# Altium schematic sheet POWER.SchDoc(U_POWER)
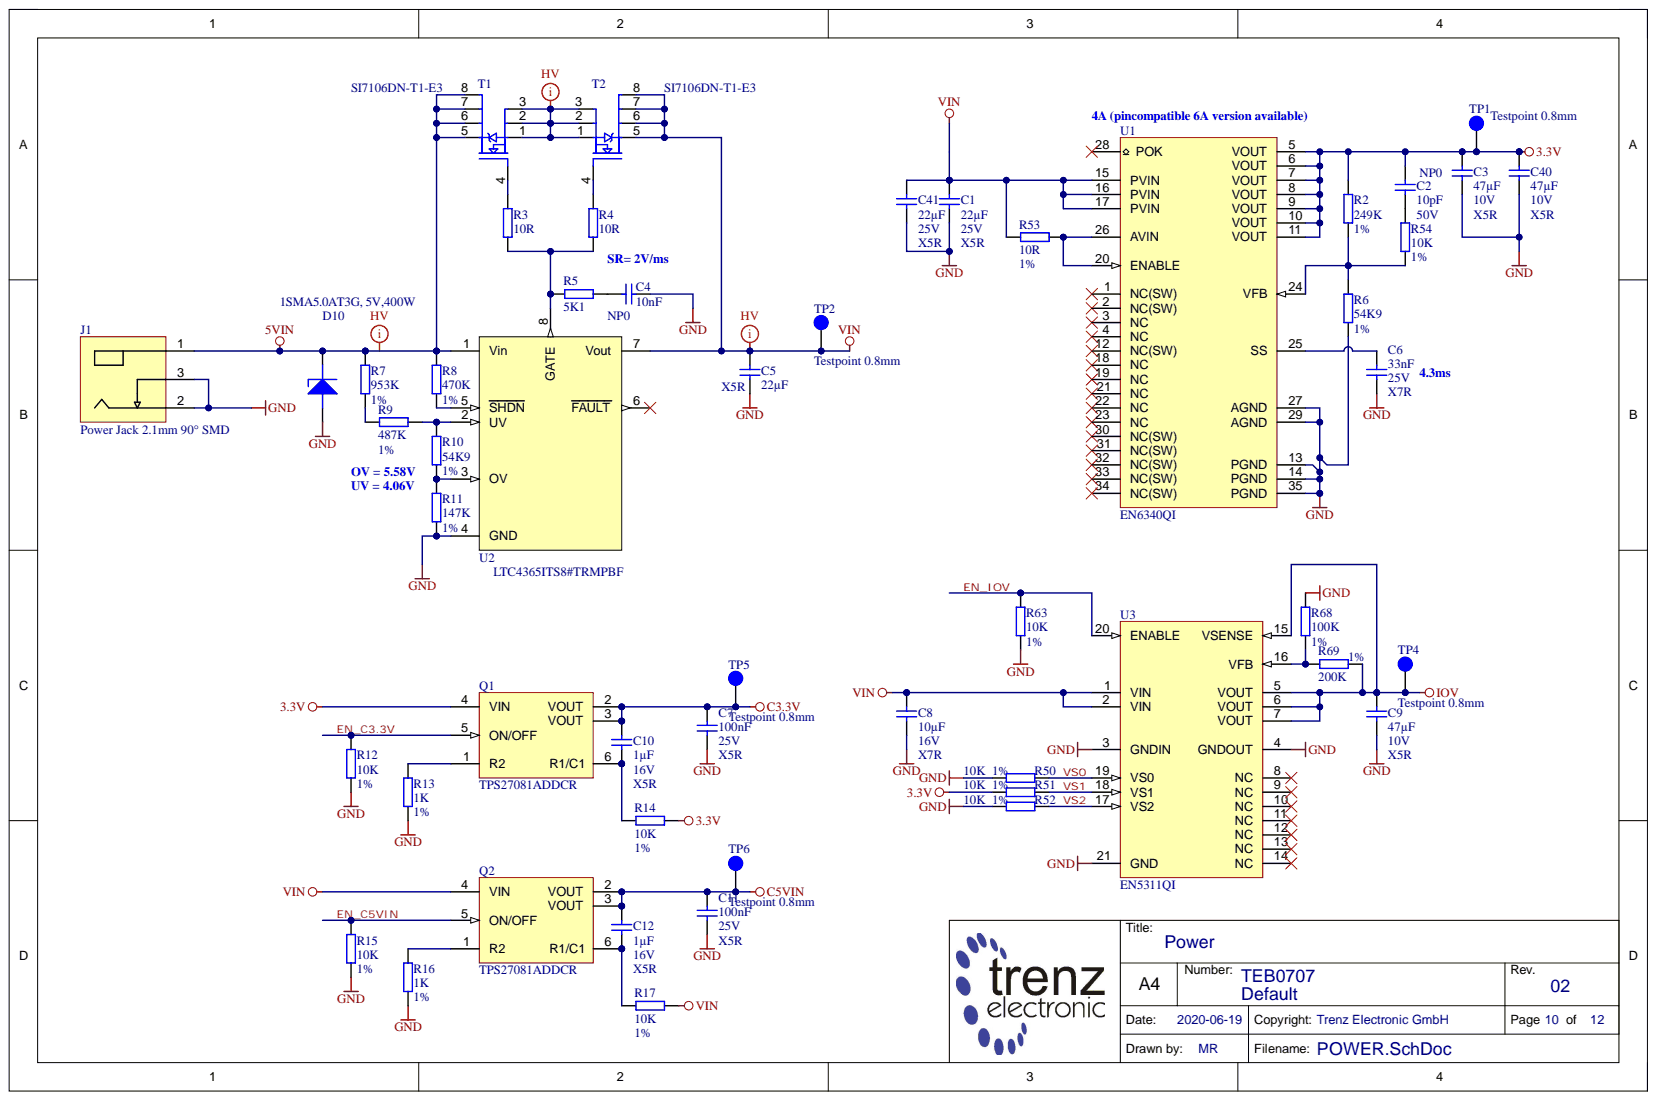
[diagram p0: POWER.SchDoc]

## Parts
C1  22µF  Technology: X5R  Voltage: 25V  [CAPC3216X180N]
C2  10pF  Technology: NP0  Voltage: 50V  [CAPC1005X55N]
C3  47µF  Technology: X5R  Voltage: 10V  [CAPC2012X135N]
C4  10nF  [CAPC1608X90N]
C5  22µF  Technology: X5R  [CAPC3216X180N]
C6  33nF  Technology: X7R  Voltage: 25V  [CAPC1005X55N]
C7  100nF  Technology: X5R  Voltage: 25V  [CAPC1005X55N]
C8  10µF  Technology: X7R  Voltage: 16V  [CAPC2012X135N]
C9  47µF  Technology: X5R  Voltage: 10V  [CAPC2012X135N]
C10  1µF  Technology: X5R  Voltage: 16V  [CAPC1005X55N_V]
C11  100nF  Technology: X5R  Voltage: 25V  [CAPC1005X55N]
C12  1µF  Technology: X5R  Voltage: 16V  [CAPC1005X55N]
C40  47µF  Technology: X5R  Voltage: 10V  [CAPC2012X135N]
C41  22µF  Technology: X5R  Voltage: 25V  [CAPC3216X180N]
D10  1SMA5.0AT3G, 5V,400W  [DIOM5226X220N]
J1  Power Jack 2.1mm 90° SMD  [694106106102]
Q1  TPS27081ADDCR  [SOT95P280X110-6N]
Q2  TPS27081ADDCR  [SOT95P280X110-6N]
R2  249K  Tolerance: 1%  [RESC1005X40N]
R3  10R  [RESC1005X40N]
R4  10R  [RESC1005X40N]
R5  5K1  [RESC1005X40N]
R6  54K9  Tolerance: 1%  [RESC1005X40N]
R7  953K  Tolerance: 1%  [RESC1005X40N]
R8  470K  Tolerance: 1%  [RESC1005X40N]
R9  487K  Tolerance: 1%  [RESC1005X40N]
R10  54K9  Tolerance: 1%  [RESC1005X40N]
R11  147K  Tolerance: 1%  [RESC1005X40N]
R12  10K  Tolerance: 1%  [RESC1005X40N]
R13  1K  Tolerance: 1%  [RESC1005X40N]
R14  10K  Tolerance: 1%  [RESC1005X40N]
R15  10K  Tolerance: 1%  [RESC1005X40N]
R16  1K  Tolerance: 1%  [RESC1005X40N]
R17  10K  Tolerance: 1%  [RESC1005X40N]
R50  10K  Tolerance: 1%  [RESC1005X40N]
R51  10K  Tolerance: 1%  [RESC1005X40N]
R52  10K  Tolerance: 1%  [RESC1005X40N]
R53  10R  Tolerance: 1%  [RESC1005X40N]
R54  10K  Tolerance: 1%  [RESC1005X40N]
R63  10K  Tolerance: 1%  [RESC1005X40N]
R68  100K  Tolerance: 1%  [RESC1005X40N]
R69  200K  Tolerance: 1%  [RESC1005X40N]
T1  SI7106DN-T1-E3  [Si7106]
T2  SI7106DN-T1-E3  [Si7106]
TP1  Testpoint 0.8mm  [TP_0.8mm]
TP2  Testpoint 0.8mm  [TP_0.8mm]
TP4  Testpoint 0.8mm  [TP_0.8mm]
TP5  Testpoint 0.8mm  [TP_0.8mm]
TP6  Testpoint 0.8mm  [TP_0.8mm]
U1  EN6340QI  [EN6363]
U2  LTC4365ITS8#TRMPBF  [TSOT-23-8]
U3  EN5311QI  [EN5311QI]

## Nets
3.3V: C2.1 C3.2 C40.2 Q1.4(VIN) R2.1 R14.2 R51.2 TP1.1 U1.5(VOUT) U1.6(VOUT) U1.7(VOUT) U1.8(VOUT) U1.9(VOUT) U1.10(VOUT) U1.11(VOUT)
5VIN: D10.1 J1.1 R7.1 R8.1 T1.5 T1.6 T1.7 T1.8 U2.1(Vin)
C3.3V: C7.2 C10.2 Q1.2(VOUT) Q1.3(VOUT) TP5.1
C5VIN: C11.2 C12.2 Q2.2(VOUT) Q2.3(VOUT) TP6.1
GND: C1.1 C3.1 C4.2 C5.1 C6.2 C7.1 C8.2 C9.2 C11.1 C40.1 C41.1 D10.2 J1.2 J1.3 R6.2 R11.2 R12.2 R13.1 R15.2 R16.1 R50.2 R52.2 R63.2 R68.1 U1.13(PGND) U1.14(PGND) U1.27(AGND) U1.29(AGND) U1.35(PGND) U2.4(GND) U3.3(GNDIN) U3.4(GNDOUT) U3.21(GND)
IOV: C9.1 R69.2 TP4.1 U3.5(VOUT) U3.6(VOUT) U3.7(VOUT) U3.15(VSENSE)
VIN: C1.2 C5.2 C8.1 C41.2 Q2.4(VIN) R17.2 R53.1 T2.5 T2.6 T2.7 T2.8 TP2.1 U1.15(PVIN) U1.16(PVIN) U1.17(PVIN) U2.7(Vout) U3.1(VIN) U3.2(VIN)
EN_C3.3V: Q1.5(ON/OFF) R12.1
EN_C5VIN: Q2.5(ON/OFF) R15.1
EN_IOV: R63.1 U3.20(ENABLE)
VS0: R50.1 U3.19(VS0)
VS1: R51.1 U3.18(VS1)
VS2: R52.1 U3.17(VS2)
NetC2_2: C2.2 R54.1
NetC4_1: C4.1 R5.2
NetC6_1: C6.1 U1.25(SS)
NetC10_1: C10.1 Q1.6(R1/C1) R14.1
NetC12_1: C12.1 Q2.6(R1/C1) R17.1
NetQ1_1: Q1.1(R2) R13.2
NetQ2_1: Q2.1(R2) R16.2
NetR2_2: R2.2 R6.1 R54.2 U1.24(VFB)
NetR3_1: R3.1 T1.4
NetR3_2: R3.2 R4.2 R5.1 U2.8(GATE)
NetR4_1: R4.1 T2.4
NetR7_2: R7.2 R9.1
NetR8_2: R8.2 U2.5(SHDN)
NetR9_2: R9.2 R10.1 U2.2(UV)
NetR10_2: R10.2 R11.1 U2.3(OV)
NetR53_2: R53.2 U1.20(ENABLE) U1.26(AVIN)
NetR68_2: R68.2 R69.1 U3.16(VFB)
NetT1_1: T1.1 T1.2 T1.3 T2.1 T2.2 T2.3

Not connected: U1 1(NC(SW)) 2(NC(SW)) 3(NC) 4(NC) 12(NC(SW)) 18(NC) 19(NC) 21(NC) 22(NC) 23(NC) 28(POK) 30(NC(SW)) 31(NC(SW)) 32(NC(SW)) 33(NC(SW)) 34(NC(SW)); U2 6(FAULT); U3 8(NC) 9(NC) 10(NC) 11(NC) 12(NC) 13(NC) 14(NC)

## Library data of the parts
C1 C5 C41 = 24886: KON 22µF 25V X5R  10%  SMD BF 3216 (1206); Database Table Name: C_3216_1206; Mixed: SMT; Preferred: Yes; Temperature-Range: -55/+85; Value: 22u; AN: 24886; Case: 3216 (1206); Component Kind: Standard; Library Name: TE.DbLib; Tolerance: 10%
  C5: Voltage: 25V
C2 = 22597: KON 10pF 50V NP0 5% SMD BF 1005 (0402); Database Table Name: C_1005_0402; Mixed: SMT; Preferred: Yes; Value: 10p; AN: 22597; Case: 1005 (0402); Component Kind: Standard; Library Name: TE.DbLib; Tolerance: 5%
C3 C9 C40 = 26515: KON 47µF 10V  X5R 20% SMD BF 2012 (0805); Database Table Name: C_2012_0805; Mixed: SMT; Temperature-Range: -55/+85; Value: 47u; AN: 26515; Case: 2012 (0805); Component Kind: Standard; Library Name: TE.DbLib
C4 = 23662: KON 10nF 16V C0G SMD BF 1608 (0603); Database Table Name: C_1608_0603; Mixed: SMT; Value: 10n; AN: 23662; Case: 1608 (0603); Component Kind: Standard; Library Name: TE.DbLib; Technology: NP0; Voltage: 16V
C6 = 23916: KON 33nF 25V X7R SMD BF 1005 (0402); Database Table Name: C_1005_0402; Mixed: SMT; Preferred: Yes; Temperature-Range: -55/+125; Value: 33n; AN: 23916; Case: 1005 (0402); Component Kind: Standard; Library Name: TE.DbLib
C7 C11 = 22472: KON 100nF 25V X5R ±10% SMD BF 1005 (0402); Database Table Name: C_1005_0402; Mixed: SMT; Preferred: Yes; Temperature-Range: -55/+85; Value: 100n; AN: 22472; Case: 1005 (0402); Component Kind: Standard; Library Name: TE.DbLib
C8 = 24380: KON 10µF 16V X7R 10% SMD BF 2012 (0805); Database Table Name: C_2012_0805; Mixed: SMT; Preferred: Yes; Temperature-Range: -55/+125; Value: 10u; AN: 24380; Case: 2012 (0805); Component Kind: Standard; Library Name: TE.DbLib; Tolerance: 10%
C10 C12 = 26579: KON 1µF 16V X5R 10% SMD BF 1005 (0402); Database Table Name: C_1005_0402; Mixed: SMT; Temperature-Range: -55/+85; Value: 1u; AN: 26579; Case: 1005 (0402); Component Kind: Standard; Library Name: TE.DbLib; Tolerance: 10%
D10 = 26828: TVS, Unidirectional, 5V, 400W; Database Table Name: DIODE; Mixed: SMT; AN: 26828; Component Kind: Standard; Extra: 1SMA5.0AT3G; H-ART: 1SMA5.0AT3G; Library Name: TE.DbLib; HelpURL: B:\ad\adlib\datasheet\1SMAxxAT3G
J1 = 26873: Database Table Name: CON_PWR; Mixed: SMT; Trenz-Stock: Yes; AN: 26873; Component Kind: Standard; Description: Power Jack 2.1mm 90° SMD; Extra: 694106106102; H-ART: 694106106102; Library Name: TE.DbLib; HelpURL: B:\ad\adlib\datasheet\694106106102
Q1 Q2 = 29262: Database Table Name: SWITCH; Extra: 1.2V - 8V, 3A PFET High-Side Load Switch; Mixed: SMT; Temperature-Range: -40/+85; AN: 29262; Case: SOT23-6; Component Kind: Standard; Description: TPS27081ADDCR; H-ART: TPS27081ADDCR; Library Name: TE.DbLib; HelpURL: b:\AD\adlib\datasheet\TPS27081A\
R2 = 26068: WID SMD 249K 1% 0,063W  BF 1005 (0402); Database Table Name: R_1005_0402; Mixed: SMT; AN: 26068; Case: 1005 (0402); Component Kind: Standard; Library Name: TE.DbLib; Technology: TK100; Value: 249K
R3 R4 R53 = 22844: WID SMD 10R 1% 0,063W  BF 1005 (0402); Database Table Name: R_1005_0402; Mixed: SMT; Preferred: Yes; Value: 10; AN: 22844; Case: 1005 (0402); Component Kind: Standard; Library Name: TE.DbLib; Technology: TK100
  R3 R4: Tolerance: 1%
R5 = 23125: WID SMD 5K1 1% 0,063W BF 1005 (0402); Database Table Name: R_1005_0402; Mixed: SMT; Value: 5.1K; AN: 23125; Case: 1005 (0402); Component Kind: Standard; Library Name: TE.DbLib; Technology: TK100; Tolerance: 1%
R6 R10 = 24749: WID SMD 54K9 1% 0,063W  BF 1005 (0402); Database Table Name: R_1005_0402; Mixed: SMT; Value: 54.9K; AN: 24749; Case: 1005 (0402); Component Kind: Standard; Library Name: TE.DbLib; Technology: TK100
R7 = 25627: WID SMD 953K 1% 0,063W; Database Table Name: R_1005_0402; Extra: BF 1005 (0402); Mixed: SMT; AN: 25627; Case: 1005 (0402); Component Kind: Standard; Library Name: TE.DbLib; Technology: TK100; Value: 953K
R8 = 23847: WID SMD 470K 1% 0,063W  BF 1005 (0402); Database Table Name: R_1005_0402; Mixed: SMT; AN: 23847; Case: 1005 (0402); Component Kind: Standard; Library Name: TE.DbLib; Technology: TK100; Value: 470K
R9 = 28866: WID SMD 487K 1% 0,063W  BF 1005 (0402); Database Table Name: R_1005_0402; Mixed: SMT; AN: 28866; Case: 1005 (0402); Component Kind: Standard; Library Name: TE.DbLib; Technology: TK100; Value: 487K
R11 = 26470: WID SMD 147K 1% 0,063W; Database Table Name: R_1005_0402; Extra: BF 1005 (0402); Mixed: SMT; Preferred: Yes; AN: 26470; Case: 1005 (0402); Component Kind: Standard; Library Name: TE.DbLib; Technology: TK100; Value: 147K
R12 R14 R15 R17 R50-R52 R54 R63 = 22750: WID SMD 10K 1% 0,063W BF 1005 (0402); Database Table Name: R_1005_0402; H-ART: RC0402FR-0710KL; Mixed: SMT; Preferred: Yes; AN: 22750; Case: 1005 (0402); Component Kind: Standard; Library Name: TE.DbLib; Technology: TK100; Value: 10K
R13 R16 = 22752: WID SMD 1K 1% 0,063W  BF 1005 (0402); Database Table Name: R_1005_0402; H-ART: RC0402FR-071KL; Mixed: SMT; Preferred: Yes; AN: 22752; Case: 1005 (0402); Component Kind: Standard; Library Name: TE.DbLib; Technology: TK100; Value: 1K
R68 = 22558: WID SMD 100K 1% 0,063W  BF 1005 (0402); Database Table Name: R_1005_0402; H-ART: RC0402FR-13100KL; Mixed: SMT; Preferred: Yes; AN: 22558; Case: 1005 (0402); Component Kind: Standard; Library Name: TE.DbLib; Technology: TK100; Value: 100K
R69 = 23846: WID SMD 200K 1% 0,063W  BF 1005 (0402); Database Table Name: R_1005_0402; H-ART: RC0402FR-07200KL; Mixed: SMT; Preferred: Yes; AN: 23846; Case: 1005 (0402); Component Kind: Standard; Library Name: TE.DbLib; Technology: TK100; Value: 200K
T1 T2 = 29027: Database Table Name: TRANSISTOR; Extra: Mosfet N-Kanal 20V 12.5A 6.2mOhm; Mixed: SMT; Temperature-Range: -55/+150 (Tj abs); Value: 12.5A; Voltage: 20V; AN: 29027; Case: PowerPAK 1212-8; Component Kind: Standard; Description: SI7106DN-T1-E3; H-ART: SI7106DN-T1-E3; Library Name: TE.DbLib; HelpURL: b:\AD\adlib\datasheet\Si7106DN\
TP1 TP2 TP4-TP6 = 26289: Database Table Name: PCB; Mixed: MECH; AN: 26289; Component Kind: Standard; Description: Testpoint 0.8mm; Library Name: TE.DbLib
U1 = 29101: Database Table Name: DCDC; Extra: 6.6V 4A Intel Enpirion Step-Down DC-DC Switching Converter; Mixed: SMT; Temperature-Range: -40/+85; AN: 29101; Component Kind: Standard; Description: EN6340QI; H-ART: EN6340QI; Library Name: TE.DbLib; HelpURL: b:\AD\adlib\datasheet\EN6340QI\
U2 = 25923: Database Table Name: SUPERVISOR; Extra: Overvoltage,Undervoltage and ReverseSupply Protection Controller; Mixed: SMT; Temperature-Range: -40/+85; Trenz-Stock: Yes; AN: 25923; Case: TS8; Component Kind: Standard; Description: LTC4365ITS8#TRMPBF; H-ART: LTC4365ITS8#TRMPBF; Library Name: TE.DbLib; Storage-Temperature: -65/+150(Abs); HelpURL: b:\AD\adlib\datasheet\LTC4365xTS8\
U3 = 25492: Database Table Name: DCDC; Extra: 1A PowerSoC Synchronous  Buck Regulator With Integrated Inductor; Mixed: SMT; Preferred: Yes; Temperature-Range: -40/+85; AN: 25492; Case: QFN20; Component Kind: Standard; Description: EN5311QI; H-ART: EN5311QI; Library Name: TE.DbLib; Trenz-Stock: Yes; HelpURL: B:\AD\adlib\datasheet\EN5311QI
